# Altium schematic sheet Sheet5.SchDoc(Sheet5)
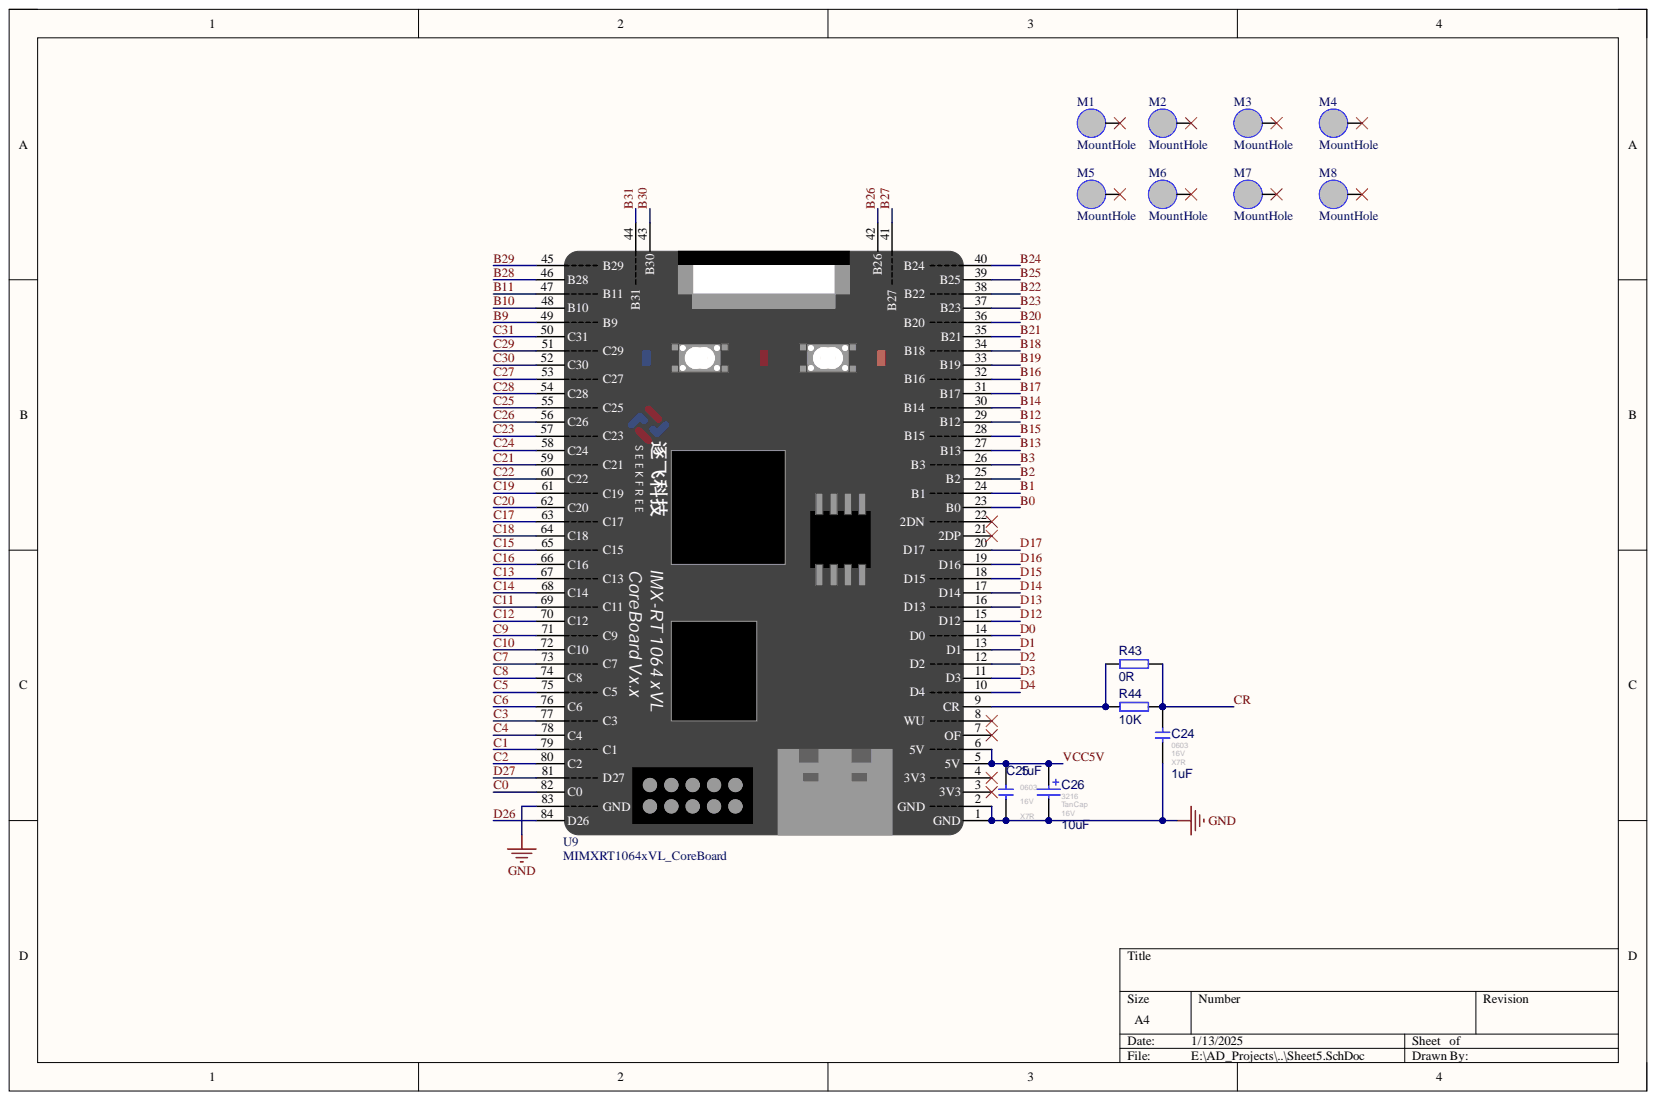
[diagram p0: Sheet5.SchDoc]

## Parts
C24  1uF 16V X7R  value 1uF  Type: X7R  Voltage: 16V  [0603]
C25  1uF 16V X7R  value 1uF  [0603]
C26  value 10uF  Type: TanCap  Voltage: 16V  [3216]  (hidden comment: T-S 10uF 3216 16V)
M1  MountHole  [M3-MountHole]  (no BOM)
M2  MountHole  [M3-MountHole]  (no BOM)
M3  MountHole  [M3-MountHole]  (no BOM)
M4  MountHole  [M3-MountHole]  (no BOM)
M5  MountHole  [M3-MountHole]  (no BOM)
M6  MountHole  [M3-MountHole]  (no BOM)
M7  MountHole  [M3-MountHole]  (no BOM)
M8  MountHole  [M3-MountHole]  (no BOM)
R43  value 0R  [RESC1608[0603]S-L]  (hidden comment: 0R ±1%)
R44  value 10K  [RESC1608[0603]S-L]  (hidden comment: 10K ±1%)
U9  MIMXRT1064xVL_CoreBoard  [MIMXRT1064xVL_CoreBoard - 3D]

## Nets
GND: C24.1 C25.1 C26.2 U9.1(GND) U9.2 U9.83
B0: U9.23(B0)
B1: U9.24
B2: U9.25(B2)
B3: U9.26
B9: U9.49
B10: U9.48(B10)
B11: U9.47
B12: U9.29(B12)
B13: U9.27(B13)
B14: U9.30
B15: U9.28
B16: U9.32
B17: U9.31(B17)
B18: U9.34
B19: U9.33(B19)
B20: U9.36
B21: U9.35(B21)
B22: U9.38
B23: U9.37(B23)
B24: U9.40
B25: U9.39(B25)
B26: U9.42(B26)
B27: U9.41
B28: U9.46(B28)
B29: U9.45
B30: U9.43(B30)
B31: U9.44
C0: U9.82(C0)
C1: U9.79
C2: U9.80(C2)
C3: U9.77
C4: U9.78(C4)
C5: U9.75
C6: U9.76(C6)
C7: U9.73
C8: U9.74(C8)
C9: U9.71
C10: U9.72(C10)
C11: U9.69
C12: U9.70(C12)
C13: U9.67
C14: U9.68(C14)
C15: U9.65
C16: U9.66(C16)
C17: U9.63
C18: U9.64(C18)
C19: U9.61
C20: U9.62(C20)
C21: U9.59
C22: U9.60(C22)
C23: U9.57
C24: U9.58(C24)
C25: U9.55
C26: U9.56(C26)
C27: U9.53
C28: U9.54(C28)
C29: U9.51
C30: U9.52(C30)
C31: U9.50(C31)
CR: C24.2 R43.2 R44.2
D0: U9.14
D1: U9.13(D1)
D2: U9.12
D3: U9.11(D3)
D4: U9.10
D12: U9.15(D12)
D13: U9.16
D14: U9.17(D14)
D15: U9.18
D16: U9.19(D16)
D17: U9.20
D26: U9.84(D26)
D27: U9.81
VCC5V: C25.2 C26.1 U9.5(5V) U9.6
NetR43_1: R43.1 R44.1 U9.9(CR)

Not connected: M1 1; M2 1; M3 1; M4 1; M5 1; M6 1; M7 1; M8 1; U9 3(3V3) 4 7(OF) 8 21(2DP) 22

## Library data of the parts
C24 C25 = 1uF 0603 16V X7R: 贴片瓷片电容; MLCC; 0603(1608);; Component Kind: Standard; Revision: V1.0; Library Name: RT1064 主板 V3.0 器件封装集成库.IntLib
  C25: Type: X7R; Voltage: 16V
C26 = T-S 10uF 3216 16V: 贴片钽电容 10uF 3216 16V; Component Kind: Standard; Revision: July-2002: Re-released for DXP Platform.; Library Name: RT1064 主板 V3.0 器件封装集成库.IntLib
M1-M8 = MountHole: 固定孔; Library Name: RT1064 主板 V3.0 器件封装集成库.IntLib
R43 = Res-Chip_1: 贴片电阻; Power: 1/8W; Component Kind: Standard; Revision: V1.0; Tolerance: ±1%; Library Name: RT1064 主板 V3.0 器件封装集成库.IntLib
R44 = Res-Chip: 贴片电阻; Power: 1/8W; Component Kind: Standard; Revision: V1.0; Tolerance: ±1%; Library Name: RT1064 主板 V3.0 器件封装集成库.IntLib
U9 = MIMXRT1064xVL_CoreBoard: 逐飞科技i.MX RT1064核心板整体原理，可直接使用; Component Kind: Standard; Library Name: RT1064 主板 V3.0 器件封装集成库.IntLib
